# Altium schematic sheet CAN Nodes.SchDoc
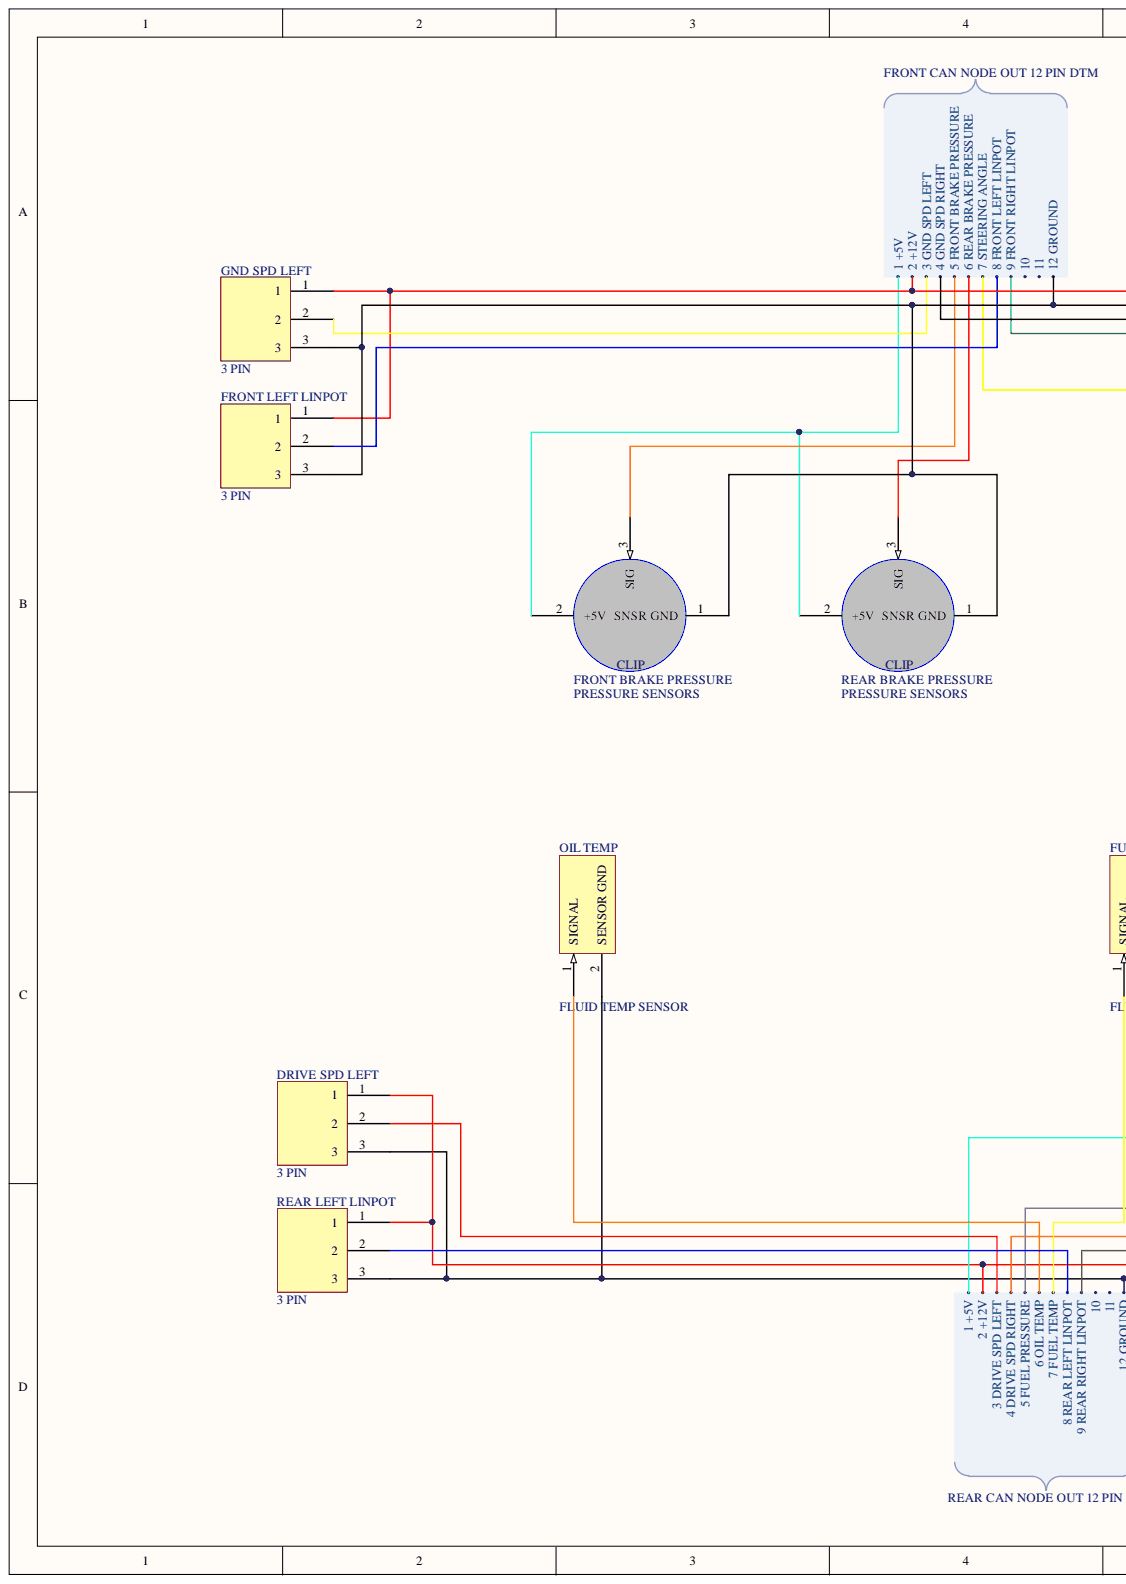
[diagram p0: CAN Nodes.SchDoc, left]
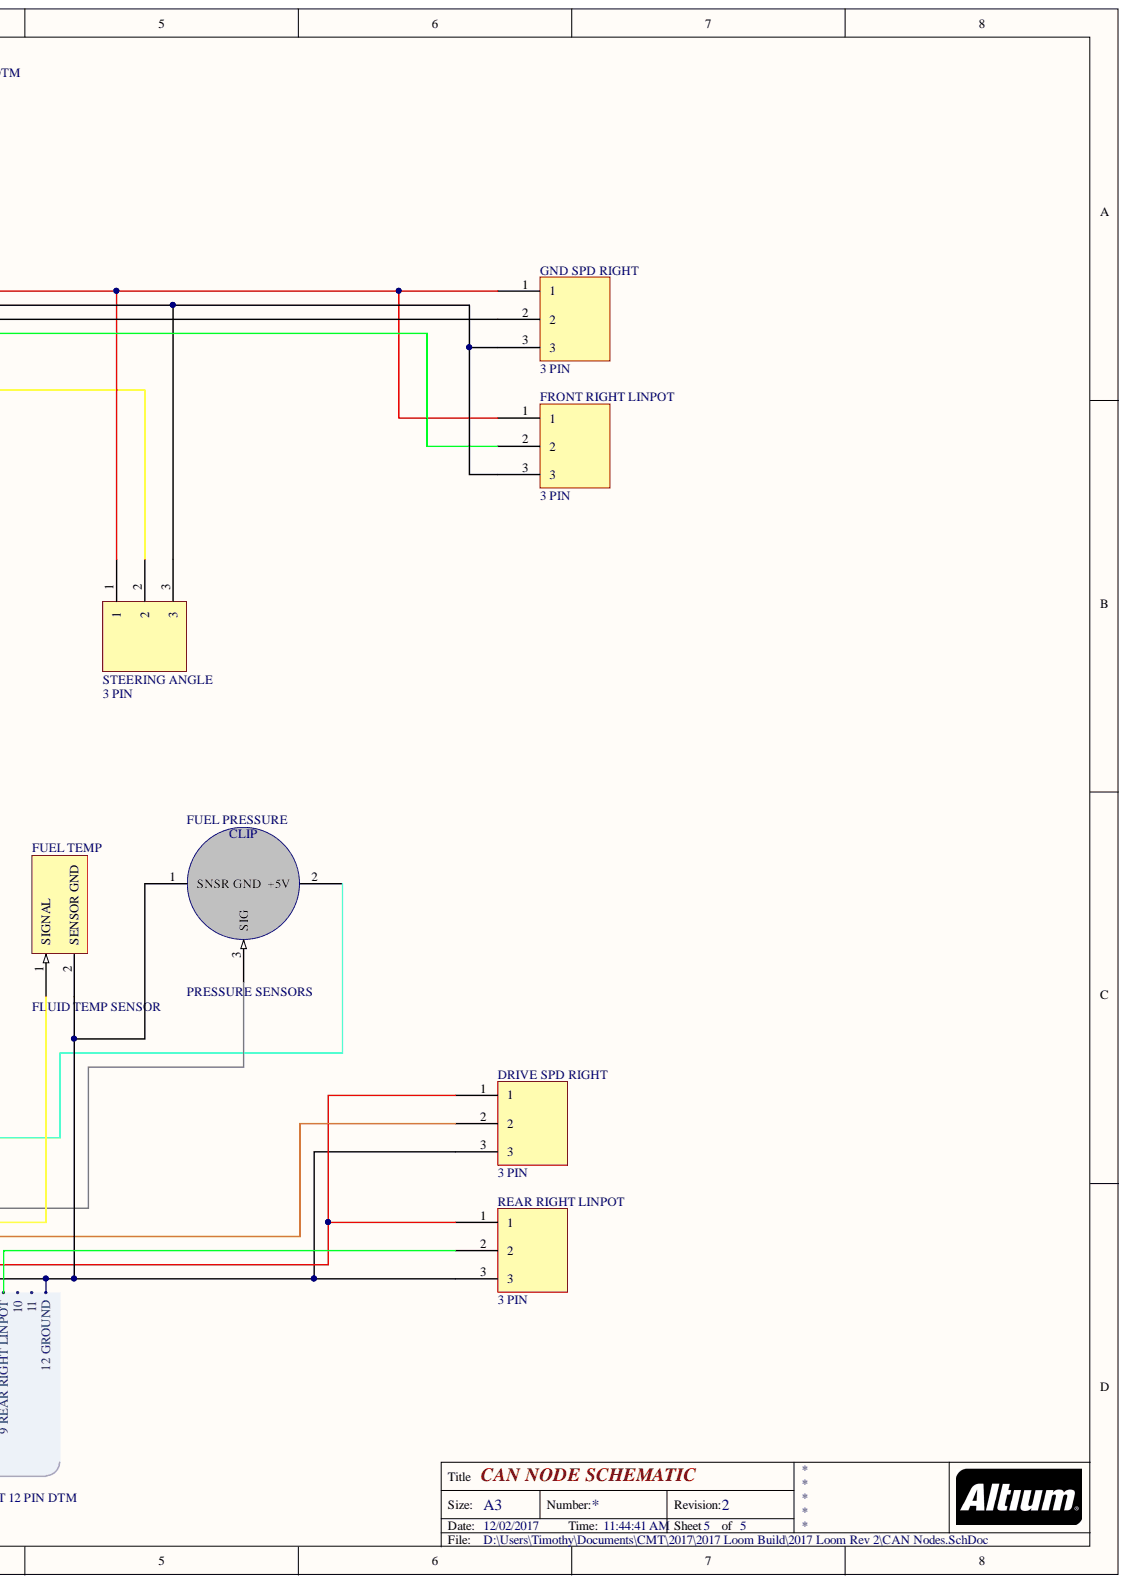
[diagram p1: CAN Nodes.SchDoc, right]

## Parts
DRIVE_SPD_LEFT  3 PIN
DRIVE_SPD_RIGHT  3 PIN
FRONT_BRAKE_PRESSURE  PRESSURE SENSORS
FRONT_LEFT_LINPOT  3 PIN
FRONT_RIGHT_LINPOT  3 PIN
FUEL_PRESSURE  PRESSURE SENSORS
FUEL_TEMP  FLUID TEMP SENSOR
GND_SPD_LEFT  3 PIN
GND_SPD_RIGHT  3 PIN
OIL_TEMP  FLUID TEMP SENSOR
REAR_BRAKE_PRESSURE  PRESSURE SENSORS
REAR_LEFT_LINPOT  3 PIN
REAR_RIGHT_LINPOT  3 PIN
STEERING_ANGLE  3 PIN

## Nets
NetDRIVE SPD LEFT_1: DRIVE_SPD_LEFT.1 DRIVE_SPD_RIGHT.1 REAR_LEFT_LINPOT.1 REAR_RIGHT_LINPOT.1
NetDRIVE SPD LEFT_3: DRIVE_SPD_LEFT.3 DRIVE_SPD_RIGHT.3 FUEL_PRESSURE.1 FUEL_TEMP.2 OIL_TEMP.2 REAR_LEFT_LINPOT.3 REAR_RIGHT_LINPOT.3
NetFRONT BRAKE PRESSURE_1: FRONT_BRAKE_PRESSURE.1 FRONT_LEFT_LINPOT.3 FRONT_RIGHT_LINPOT.3 GND_SPD_LEFT.3 GND_SPD_RIGHT.3 REAR_BRAKE_PRESSURE.1 STEERING_ANGLE.3
NetFRONT BRAKE PRESSURE_2: FRONT_BRAKE_PRESSURE.2 REAR_BRAKE_PRESSURE.2
NetFRONT LEFT LINPOT_1: FRONT_LEFT_LINPOT.1 FRONT_RIGHT_LINPOT.1 GND_SPD_LEFT.1 GND_SPD_RIGHT.1 STEERING_ANGLE.1

Not connected: DRIVE_SPD_LEFT 2; DRIVE_SPD_RIGHT 2; FRONT_BRAKE_PRESSURE 3; FRONT_LEFT_LINPOT 2; FRONT_RIGHT_LINPOT 2; FUEL_PRESSURE 2 3; FUEL_TEMP 1; GND_SPD_LEFT 2; GND_SPD_RIGHT 2; OIL_TEMP 1; REAR_BRAKE_PRESSURE 3; REAR_LEFT_LINPOT 2; REAR_RIGHT_LINPOT 2; STEERING_ANGLE 2

## Library data of the parts
FRONT_BRAKE_PRESSURE FUEL_PRESSURE REAR_BRAKE_PRESSURE = PRESSURE SENSORS: TEXAS INSTRUMENTS 150 PSI; Component Kind: Standard; Library Name: Loom Components.SchLib
FUEL_TEMP OIL_TEMP = FLUID TEMP SENSOR: FOR OIL, FUEL, WATER; Component Kind: Standard; Library Name: Loom Components.SchLib
DRIVE_SPD_LEFT DRIVE_SPD_RIGHT FRONT_LEFT_LINPOT FRONT_RIGHT_LINPOT GND_SPD_LEFT GND_SPD_RIGHT REAR_LEFT_LINPOT REAR_RIGHT_LINPOT STEERING_ANGLE = 3 PIN: Component Kind: Standard; Library Name: Loom Connectors.SchLib
